# Altium schematic sheet RF210_Solar_NEH.SchDoc(RF210_Solar_NEH)
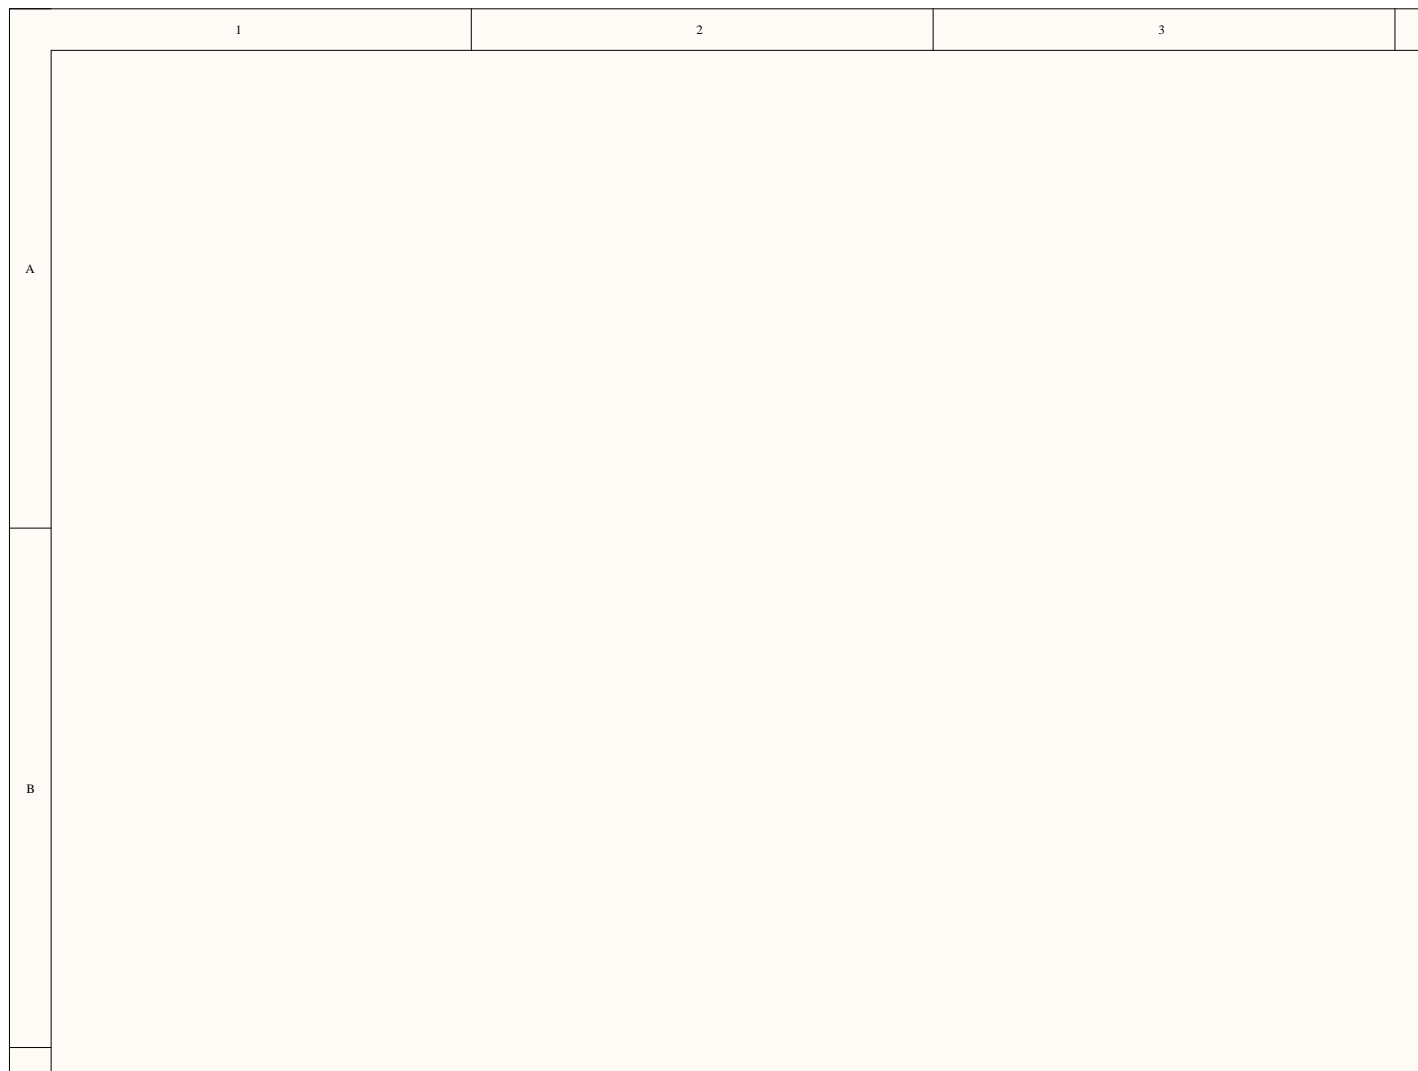
[diagram p0: RF210_Solar_NEH.SchDoc, top left]
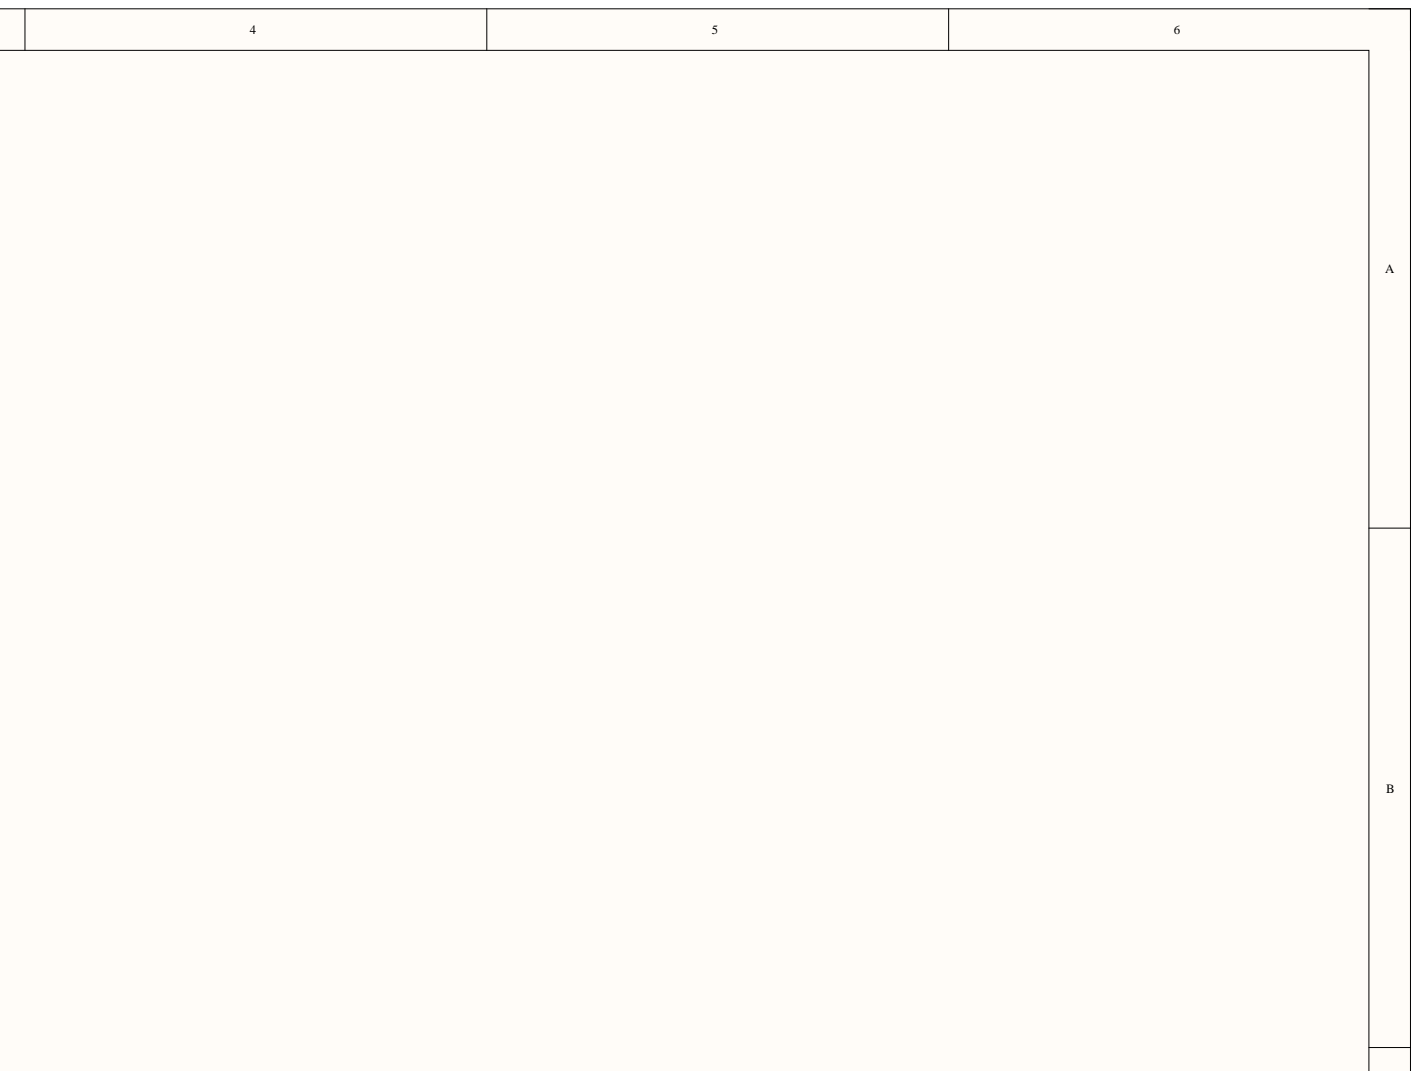
[diagram p1: RF210_Solar_NEH.SchDoc, top right]
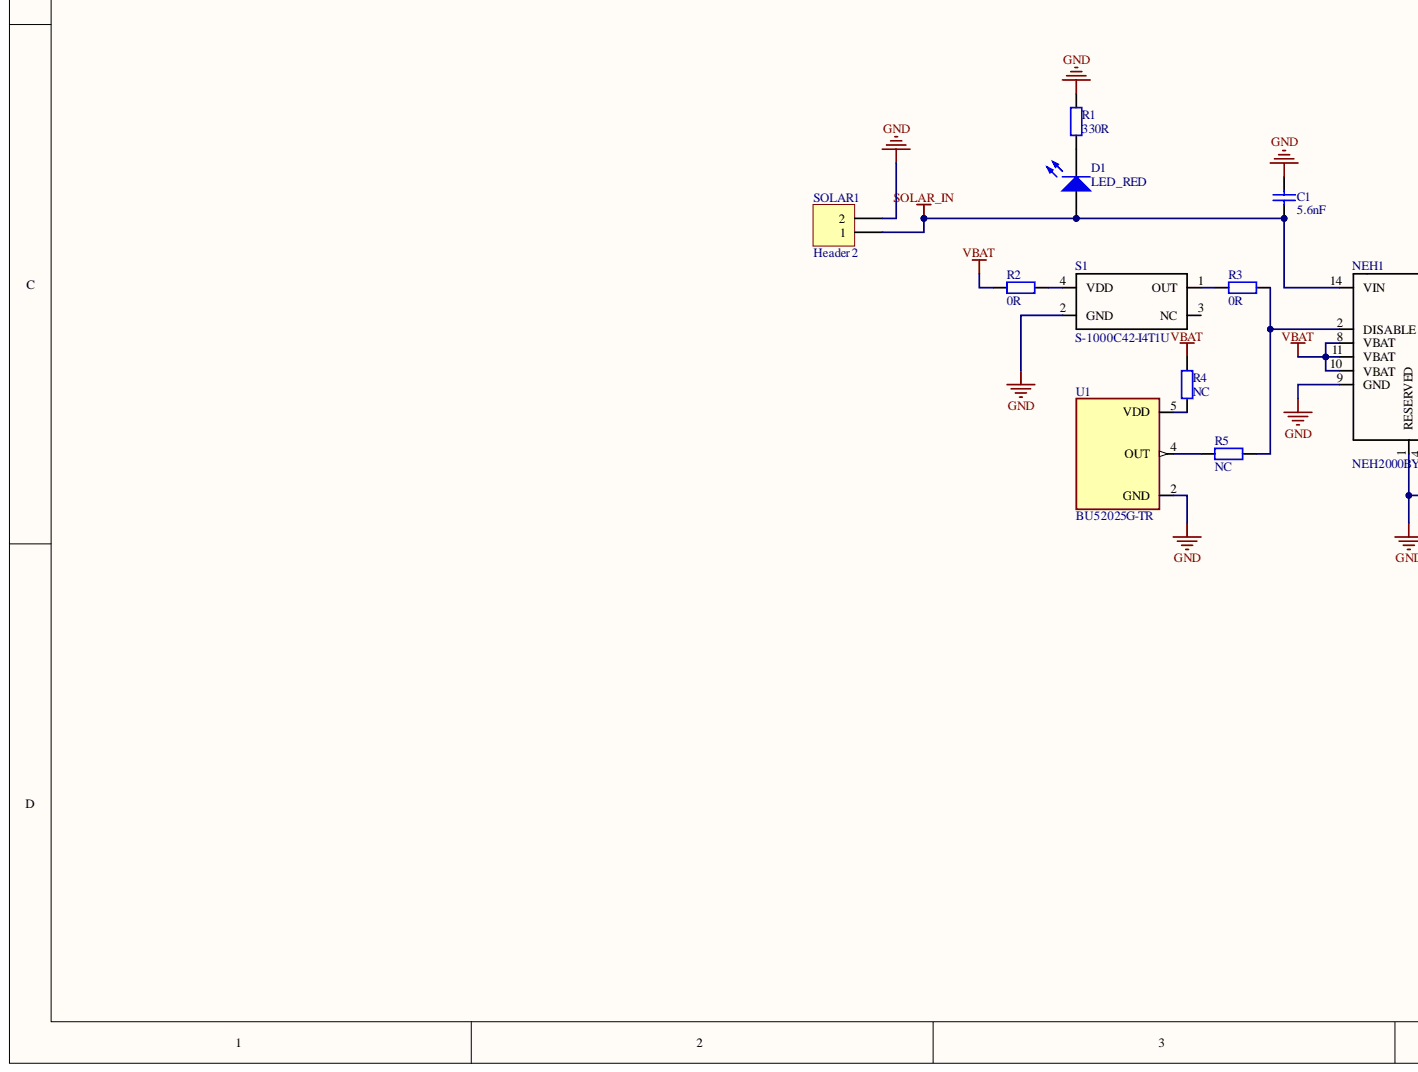
[diagram p2: RF210_Solar_NEH.SchDoc, bottom left]
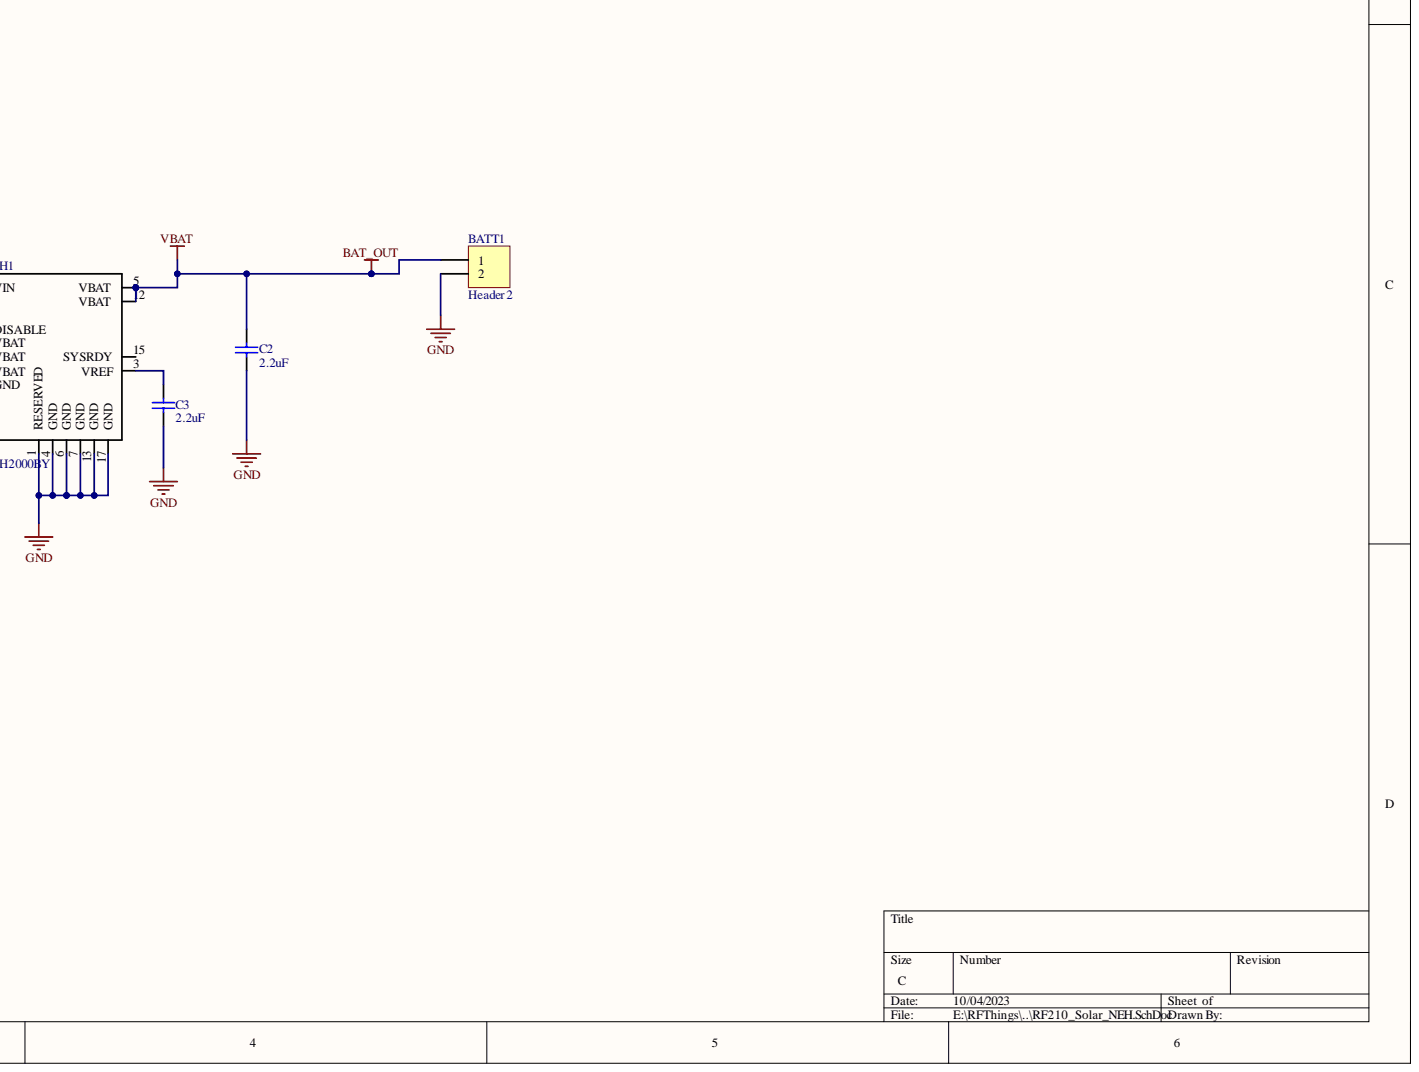
[diagram p3: RF210_Solar_NEH.SchDoc, bottom right]

## Parts
BATT1  Header 2  [HDR1X2]
C1  value 5.6nF  [rlc_402_smd]  (hidden comment: Cap)
C2  value 2.2uF  [rlc_402_smd]  (hidden comment: Cap)
C3  value 2.2uF  [rlc_402_smd]  (hidden comment: Cap)
D1  LED_RED  [CD1005-0402]
NEH1  NEH2000BY  [QFN50P300X300X90-17N]
R1  value 330R  [rlc_402_smd]  (hidden comment: Resistor)
R2  value 0R  [rlc_402_smd]  (hidden comment: Resistor)
R3  value 0R  [rlc_402_smd]  (hidden comment: Resistor)
R4  value NC  [rlc_402_smd]  (hidden comment: Resistor)
R5  value NC  [rlc_402_smd]  (hidden comment: Resistor)
S1  S-1000C42-I4T1U  [SNT-4A]
SOLAR1  Header 2  [HDR1X2]
U1  BU52025G-TR  [SOP95P280X125-5N]

## Nets
BAT_OUT: BATT1.1 C2.1 NEH1.5(VBAT) NEH1.8(VBAT) NEH1.10(VBAT) NEH1.11(VBAT) NEH1.12(VBAT) R2.2 R4.2
GND: BATT1.2 C1.1 C2.2 C3.2 NEH1.1(RESERVED) NEH1.4(GND) NEH1.6(GND) NEH1.7(GND) NEH1.9(GND) NEH1.13(GND) NEH1.17(GND) R1.1 S1.2(GND) SOLAR1.2 U1.2
SOLAR_IN: C1.2 D1.1 NEH1.14(VIN) SOLAR1.1
NetC3_1: C3.1 NEH1.3(VREF)
NetD1_2: D1.2 R1.2
NetNEH1_2: NEH1.2(DISABLE) R3.2 R5.2
NetR2_1: R2.1 S1.4(VDD)
NetR3_1: R3.1 S1.1(OUT)
NetR4_1: R4.1 U1.5
NetR5_1: R5.1 U1.4

Not connected: NEH1 15(SYSRDY); S1 3(NC)

## Library data of the parts
BATT1 SOLAR1 = Header 2: Header, 2-Pin; Component Kind: Standard; LatestRevisionDate: 17-Jul-2002; LatestRevisionNote: Re-released for DXP Platform.; Library Name: LR1110_e516v02b.SchLib; Signal Integrity: Connector; Publisher: Altium Limited
C1-C3 = Cap: Capacitor SMD; Component Kind: Standard; LatestRevisionDate: 05-oct-2007  -  Semtech; LatestRevisionNote: Re-released for DXP Platform.; Library Name: LR1110_e516v02b.SchLib; Published: 8-Jun-2000; Signal Integrity: Cap Semi; Simulation: CAP; Publisher: Altium Limited
D1 = LED: Component Kind: Standard; Description: LED; LatestRevisionDate: 05-oct-2007  -  Semtech; Library Name: LR1110_e516v02b.SchLib
R1-R5 = Resistor: Resistor SMD - IEC symbol version; Component Kind: Standard; LatestRevisionDate: 27-Jul-20  -  Semtech; Library Name: Gemini_LR1120.IntLib
U1 = BU52025G-TR: Ultra Small 3.3 V 8 uA Surface Mount Omnipolar Detection Hall IC - SSOP-5; Availability: Unavailable; Component Kind: Standard; Library Name: BU52025G-TR.IntLib; MP: BU52025G-TR; Package: None; Price: None
NEH1 = NEH2000BY: Component Kind: Standard; Library Name: NEH2000BY.SchLib
S1 = S-1000C42-I4T1U: Component Kind: Standard; Library Name: NEH2000BY.SchLib
